# Altium schematic sheet 电源.SchDoc(电源)
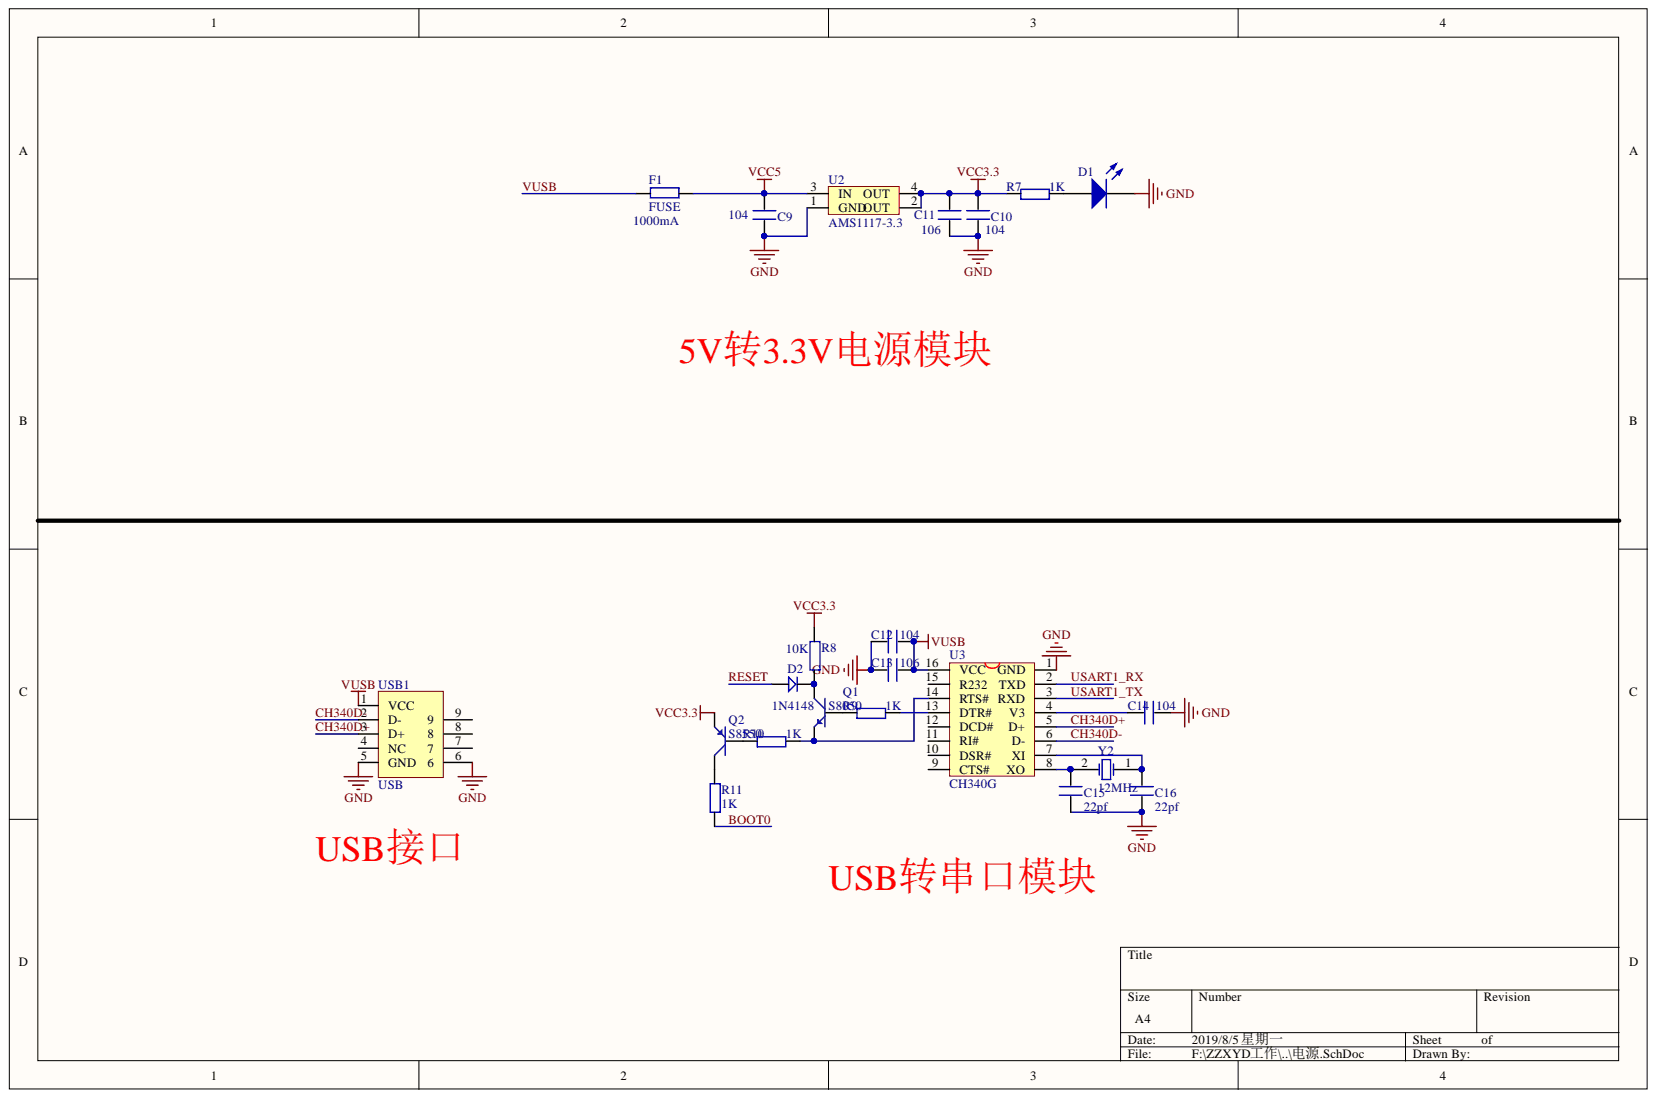
[diagram p0: 电源.SchDoc]

## Parts
C9  value 104  [0805C]  (hidden comment: CAP)
C10  value 104  [0805C]  (hidden comment: CAP)
C11  value 106  [0805C]  (hidden comment: CAP)
C12  value 104  [0805C]  (hidden comment: CAP)
C13  value 106  [0805C]  (hidden comment: CAP)
C14  value 104  [0805C]  (hidden comment: CAP)
C15  value 22pf  [0805C]  (hidden comment: CAP)
C16  value 22pf  [0805C]  (hidden comment: CAP)
D1  PWR  [0805LED]  (value not drawn)
D2  1N4148  [DIODE_SOP]
F1  FUSE  value 1000mA  [0805R]
Q1  S8050  [SOT-23]
Q2  S8550  [SOT-23]
R7  value 1K  [0805R]  (hidden comment: RES)
R8  value 10K  [0805R]  (hidden comment: RES)
R9  value 1K  [0805R]  (hidden comment: RES)
R10  value 1K  [0805R]  (hidden comment: RES)
R11  value 1K  [0805R]  (hidden comment: RES)
U2  AMS1117-3.3  [AMS1117]
U3  CH340G  [SOP-16]
USB1  USB  [MINIUSB]
Y2  12MHz  [XTAL_US]

## Nets
GND: C9.1 C10.1 C11.2 C12.2 C13.2 C14.1 C15.2 C16.2 D1.2 U2.1(GND) U3.1(GND) USB1.5(GND) USB1.6
VCC3.3: C10.2 C11.1 Q2.1 R7.1 R8.2 U2.2(OUT) U2.4(OUT)
VCC5: C9.2 F1.1 U2.3(IN)
BOOT0: R11.1
CH340D+: U3.5(D+) USB1.3(D+)
CH340D-: U3.6(D-) USB1.2(D-)
RESET: D2.1
USART1_RX: U3.2(TXD)
USART1_TX: U3.3(RXD)
VUSB: C12.1 C13.1 F1.2 U3.16(VCC) USB1.1(VCC)
NetC14_2: C14.2 U3.4(V3)
NetC15_1: C15.1 U3.8(XO) Y2.2
NetC16_1: C16.1 U3.7(XI) Y2.1
NetD1_1: D1.1 R7.2
NetD2_2: D2.2 Q1.3 R8.1
NetQ1_1: Q1.1 R10.2 U3.14(RTS#)
NetQ1_2: Q1.2 R9.1
NetQ2_2: Q2.2 R10.1
NetQ2_3: Q2.3 R11.2
NetR9_2: R9.2 U3.13(DTR#)

Not connected: U3 9(CTS#) 10(DSR#) 11(RI#) 12(DCD#) 15(R232); USB1 4(NC) 7 8 9

## Library data of the parts
C9-C16 = C: 瓷片电容; Library Name: WarShip V3 STM32103封装库.IntLib; Component Kind: Standard
D1 = LED2: Typical RED, GREEN, YELLOW, AMBER GaAs LED; Simulation: LED2; Published: 8-Jun-2000; PackageReference: 3.2X1.6X1.1; PackageDocument: Feb-2002; Library Name: WarShip V3 STM32103封装库.IntLib; LatestRevisionNote: Re-released for DXP Platform.; LatestRevisionDate: 17-Jul-2002; Component Kind: Standard; Publisher: Altium Limited
D2 = D: Library Name: WarShip V3 STM32103封装库.IntLib; Component Kind: Standard
F1 = R: 保险丝; Library Name: WarShip V3 STM32103封装库.IntLib; Component Kind: Standard
Q1 = NPN: 8050/BCW846/BCW847; Library Name: WarShip V3 STM32103封装库.IntLib; Component Kind: Standard
Q2 = PNP: 8550/BCW68; Library Name: WarShip V3 STM32103封装库.IntLib; Component Kind: Standard
R7-R11 = R: 电阻; Library Name: WarShip V3 STM32103封装库.IntLib; Component Kind: Standard
U2 = AMS1117: Library Name: WarShip V3 STM32103封装库.IntLib; Component Kind: Standard
U3 = CH340G: USB2UART; Library Name: WarShip V3 STM32103封装库.IntLib; Component Kind: Standard
USB1 = USB5: Component Kind: Standard; Library Name: ZigBee实验箱.SCHLIB
Y2 = XTAL: Crystal Oscillator; Simulation: XTAL; Published: 8-Jun-2000; Library Name: WarShip V3 STM32103封装库.IntLib; LatestRevisionNote: Re-released for DXP Platform.; LatestRevisionDate: 17-Jul-2002; Component Kind: Standard; Publisher: Altium Limited
